# Altium schematic sheet mcu+lora.SchDoc(mcu+lora)
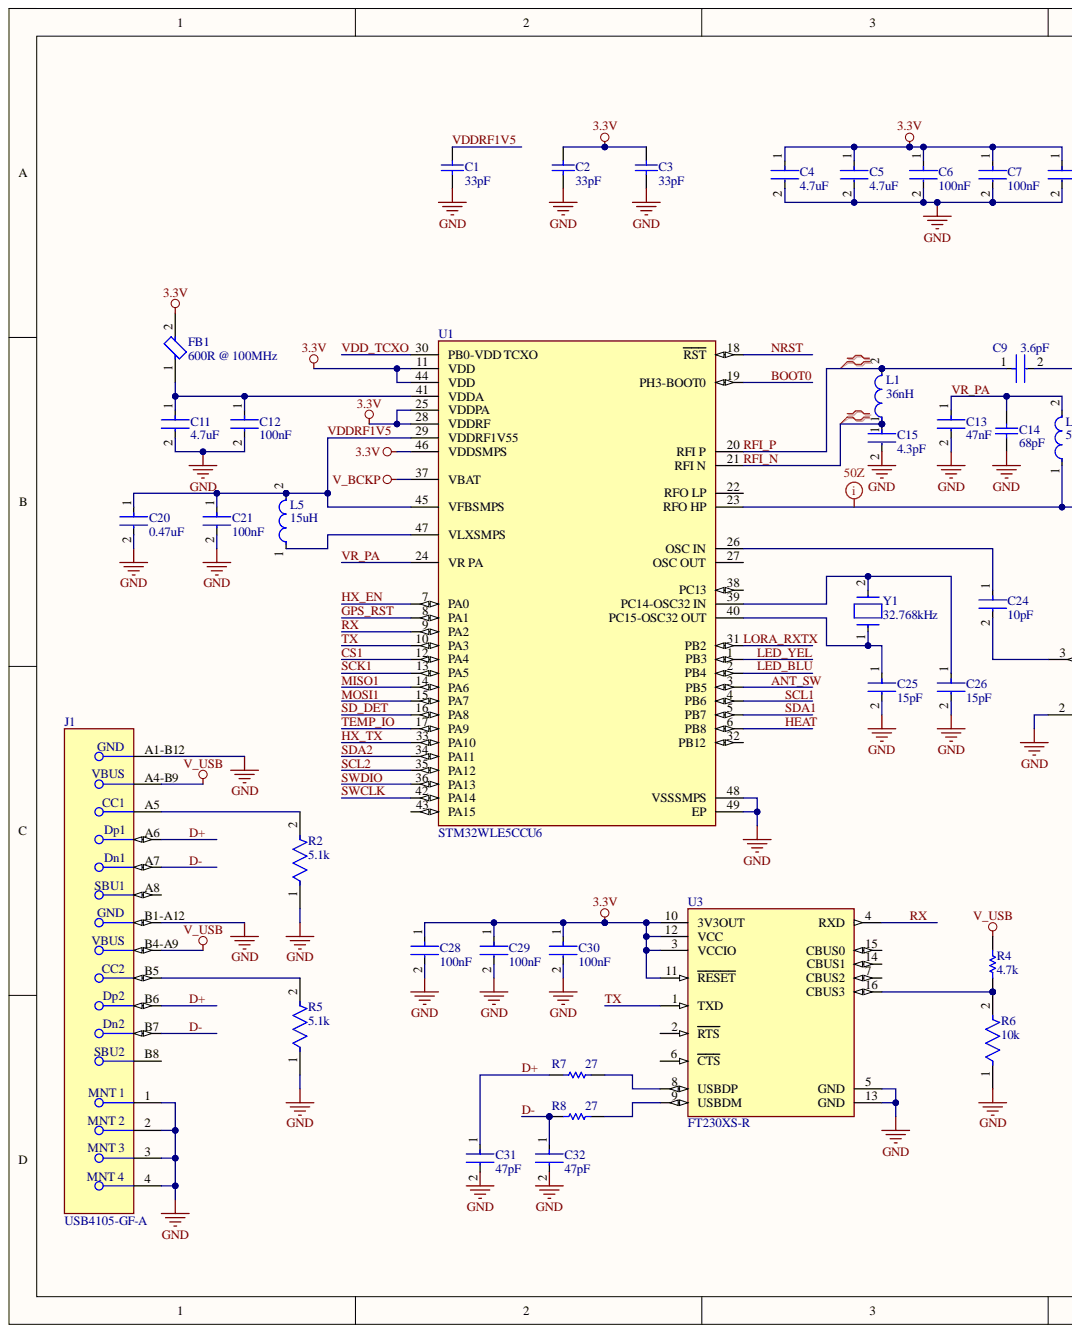
[diagram p0: mcu+lora.SchDoc, left]
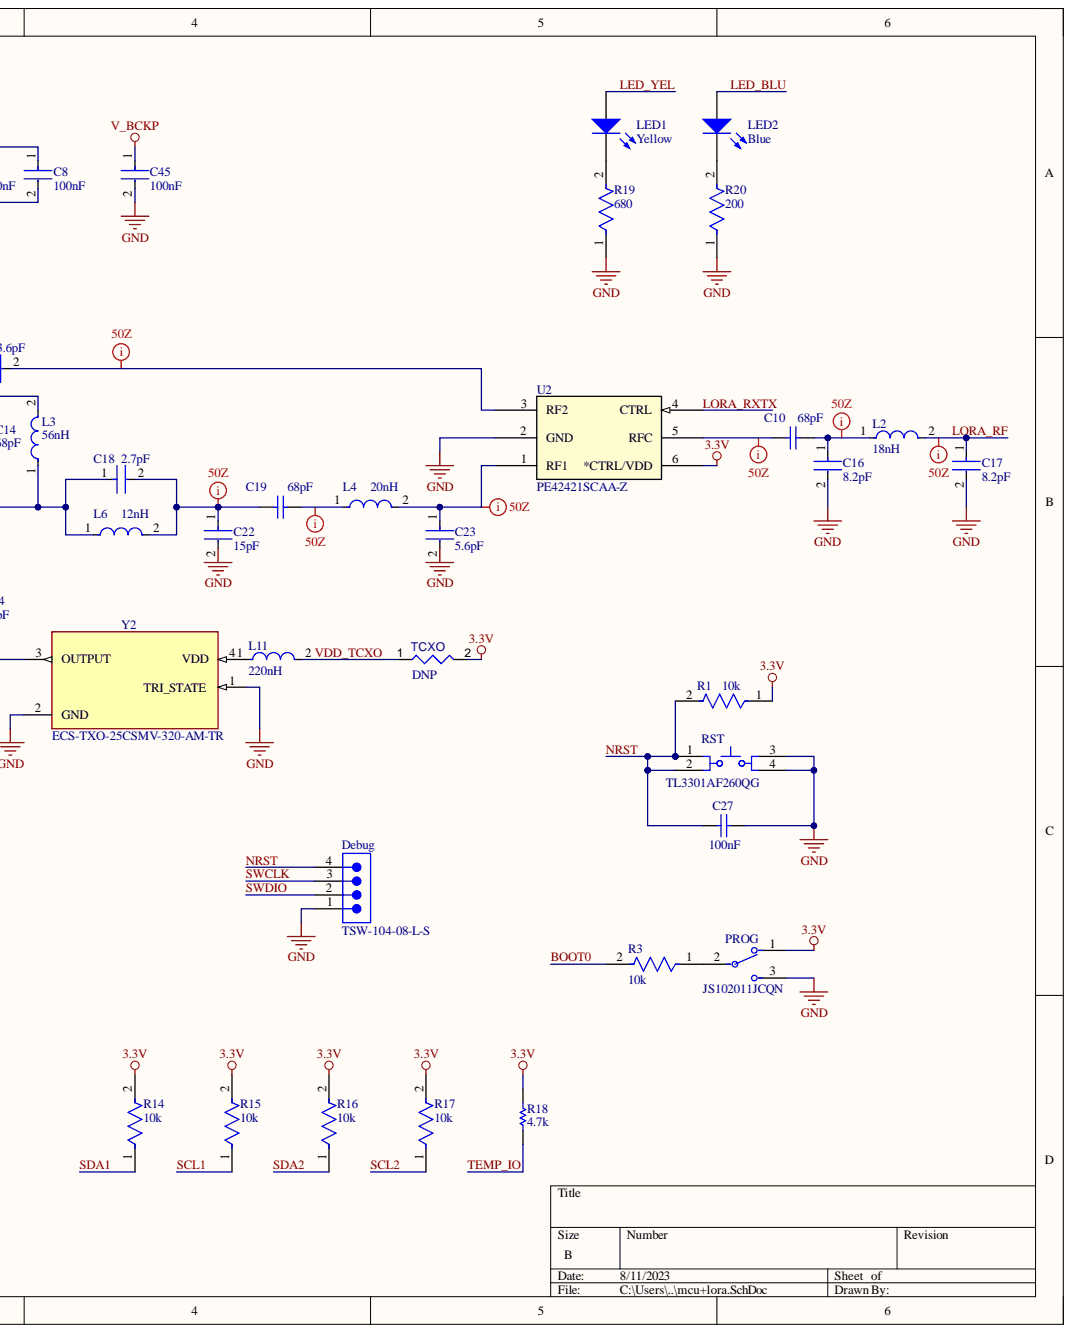
[diagram p1: mcu+lora.SchDoc, right]

## Parts
C1  33pF  [CAPC1608X90X35LL15T15]
C2  33pF  [CAPC1608X90X35LL15T15]
C3  33pF  [CAPC1608X90X35LL15T15]
C4  4.7uF  [FP-CL21-IPC_C]
C5  4.7uF  [FP-CL21-IPC_C]
C6  100nF  [FP-C0603C-CF-MFG]
C7  100nF  [FP-C0603C-CF-MFG]
C8  100nF  [FP-C0603C-CF-MFG]
C9  3.6pF  [FP-GJM155-0_05-IPC_A]
C10  68pF  [CAPC1005X55X25ML05T10]
C11  4.7uF  [FP-CL21-IPC_C]
C12  100nF  [FP-C0603C-CF-MFG]
C13  47nF  [FP-GRM155-0_05-IPC_C]
C14  68pF  [CAPC1005X55X25ML05T10]
C15  4.3pF  [FP-GJM155-0_05-IPC_A]
C16  8.2pF  [FP-GJM155-0_05-MFG]
C17  8.2pF  [FP-GJM155-0_05-MFG]
C18  2.7pF  [FP-GJM155-0_05-MFG]
C19  68pF  [CAPC1005X55X25ML05T10]
C20  0.47uF  [FP-CL21-IPC_A]
C21  100nF  [FP-C0603C-CF-MFG]
C22  15pF  [FP-GJM155-0_05-MFG]
C23  5.6pF  [FP-GJM155-0_05-IPC_C]
C24  10pF  [FP-GJM155-0_05-IPC_A]
C25  15pF  [FP-GJM155-0_05-MFG]
C26  15pF  [FP-GJM155-0_05-MFG]
C27  100nF  [CAPC1608X90X35NL15T15]
C28  100nF  [FP-C0603C-CF-MFG]
C29  100nF  [FP-C0603C-CF-MFG]
C30  100nF  [FP-C0603C-CF-MFG]
C31  47pF  [FP-C0603C-CF-MFG]
C32  47pF  [FP-C0603C-CF-MFG]
C45  100nF  [FP-C0603C-CF-MFG]
Debug  TSW-104-08-L-S  [SMTC-TSW-104-08-X-S]
FB1  600R @ 100MHz  Impedance: 600R  [FP-HZ0805-MFG]
J1  USB4105-GF-A  [FP-USB4105-GF-A-MFG]
L1  36nH  [FP-LQW15AN_80-MFG]
L2  18nH  [FP-LQW15AN_00-W0_6-0_1-MFG]
L3  56nH  [FP-LQW15AN_80-MFG]
L4  20nH  [FP-LQW15AN_00-W0_6-0_1-MFG]
L5  15uH  [FP-CB2012-MFG]
L6  12nH  [FP-LQW15AN_00-W0_6-0_1-MFG]
L11  220nH  [FP-MLZ1608-IPC_C]
LED1  Yellow  [1206_A]
LED2  Blue  [1206_A]
PROG  JS102011JCQN  [FP-JS102011JCQN-MFG]
R1  10k  [FP-RT0603-MFG]
R2  5.1k  [FP-RC1608-IPC_C]
R3  10k  [FP-RT0603-MFG]
R4  4.7k  [RESC1608X55X30NL15T15]
R5  5.1k  [FP-RC1608-IPC_C]
R6  10k  [FP-RT0603-MFG]
R7  27  [RESC1608X55X30ML15T15]
R8  27  [RESC1608X55X30ML15T15]
R14  10k  [FP-RT0603-MFG]
R15  10k  [FP-RT0603-MFG]
R16  10k  [FP-RT0603-MFG]
R17  10k  [FP-RT0603-MFG]
R18  4.7k  [RESC1608X55X30NL15T15]
R19  680  [FP-RC0603-0_55-MFG]
R20  200  [FP-RT0603-MFG]
RST  TL3301AF260QG  [ESWI-TL3301AF260QG_V]
TCXO  value DNP  [RESC0603(1608)_L]  (hidden comment: 0R3J)
U1  STM32WLE5CCU6  [FP-UFQFPN48-A0B9-IPC_C]
U2  PE42421SCAA-Z  [SC-70-6_PSM]
U3  FT230XS-R  [FP-SSOP-16-MFG]
Y1  32.768kHz  [FP-ABS05-MFG]
Y2  ECS-TXO-25CSMV-320-AM-TR  [OSC_ECS-2520S25-250-FN-TR]

## Nets
3.3V: C2.2 C3.2 C4.1 C5.1 C6.1 C7.1 C8.1 C28.1 C29.1 C30.1 FB1.2 PROG.1 R1.1 R14.2 R15.2 R16.2 R17.2 R18.2 TCXO.2 U1.11(VDD) U1.25(VDDPA) U1.28(VDDRF) U1.44(VDD) U1.46(VDDSMPS) U2.6(*CTRL/VDD) U3.3(VCCIO) U3.10(3V3OUT) U3.11(RESET) U3.12(VCC)
GND: C1.1 C2.1 C3.1 C4.2 C5.2 C6.2 C7.2 C8.2 C11.2 C12.2 C13.2 C14.1 C15.2 C16.2 C17.2 C20.2 C21.2 C22.2 C23.2 C25.2 C26.2 C27.1 C28.2 C29.2 C30.2 C31.2 C32.2 C45.2 Debug.1 J1.1 J1.2 J1.3 J1.4 J1.A1-B12 J1.B1-A12 PROG.3 R2.1 R5.1 R6.1 R19.1 R20.1 RST.3 RST.4 U1.48(VSSSMPS) U1.49(EP) U2.2(GND) U3.5(GND) U3.13(GND) Y2.1(TRI_STATE) Y2.2(GND)
V_BCKP: C45.1 U1.37(VBAT)
V_USB: J1.A4-B9 J1.B4-A9 R4.2
ANT_SW: U1.3(PB5)
BOOT0: R3.2 U1.19(PH3-BOOT0)
CS1: U1.12(PA4)
D+: C31.1 J1.A6 J1.B6 R7.1
D-: C32.1 J1.A7 J1.B7 R8.1
GPS_RST: U1.8(PA1)
HEAT: U1.6(PB8)
HX_EN: U1.7(PA0)
HX_TX: U1.33(PA10)
LED_BLU: LED2.2 U1.2(PB4)
LED_YEL: LED1.2 U1.1(PB3)
LORA_RF: C17.1 L2.2
LORA_RXTX: U1.31(PB2) U2.4(CTRL)
MISO1: U1.14(PA6)
MOSI1: U1.15(PA7)
NRST: C27.2 Debug.4 R1.2 RST.1 RST.2 U1.18(RST)
RFI_N: C15.1 L1.1 U1.21(RFI_N)
RFI_P: C9.1 L1.2 U1.20(RFI_P)
RX: U1.9(PA2) U3.4(RXD)
SCK1: U1.13(PA5)
SCL1: R15.1 U1.4(PB6)
SCL2: R17.1 U1.35(PA12)
SD_DET: U1.16(PA8)
SDA1: R14.1 U1.5(PB7)
SDA2: R16.1 U1.34(PA11)
SWCLK: Debug.3 U1.42(PA14)
SWDIO: Debug.2 U1.36(PA13)
TEMP_IO: R18.1 U1.17(PA9)
TX: U1.10(PA3) U3.1(TXD)
VDD_TCXO: L11.2 TCXO.1 U1.30(PB0-VDD_TCXO)
VDDRF1V5: C1.2 C20.1 C21.1 L5.2 U1.29(VDDRF1V55) U1.45(VFBSMPS)
VR_PA: C13.1 C14.2 L3.2 U1.24(VR_PA)
NetC9_2: C9.2 U2.3(RF2)
NetC10_1: C10.1 U2.5(RFC)
NetC10_2: C10.2 C16.1 L2.1
NetC11_1: C11.1 C12.1 FB1.1 U1.41(VDDA)
NetC18_1: C18.1 L3.1 L6.1 U1.23(RFO_HP)
NetC18_2: C18.2 C19.1 C22.1 L6.2
NetC19_2: C19.2 L4.1
NetC23_1: C23.1 L4.2 U2.1(RF1)
NetC24_1: C24.1 U1.26(OSC_IN)
NetC24_2: C24.2 Y2.3(OUTPUT)
NetC25_1: C25.1 U1.40(PC15-OSC32_OUT) Y1.1
NetC26_1: C26.1 U1.39(PC14-OSC32_IN) Y1.2
NetJ1_A5: J1.A5 R2.2
NetJ1_B5: J1.B5 R5.2
NetL5_1: L5.1 U1.47(VLXSMPS)
NetL11_1: L11.1 Y2.4(VDD)
NetLED1_1: LED1.1 R19.2
NetLED2_1: LED2.1 R20.2
NetPROG_2: PROG.2 R3.1
NetR4_1: R4.1 R6.2 U3.16(CBUS3)
NetR7_2: R7.2 U3.8(USBDP)
NetR8_2: R8.2 U3.9(USBDM)

Not connected: J1 A8 B8; U1 22(RFO_LP) 27(OSC_OUT) 32(PB12) 38(PC13) 43(PA15); U3 2(RTS) 6(CTS) 7(CBUS2) 14(CBUS1) 15(CBUS0)

## Library data of the parts
C1-C3 = CMP-2006-04137-1: Manufacturer: AVX Interconnect / Elco; Part Number: 06033A330JAT2A; Component Kind: Standard; Library Name: Altium Content Vault
C4 C5 C11 = CMP-13271-004306-1: CL21 Series 0805 4.7uF 50V ±10% Tolerance X5R Multilayer Ceramic Chip Capacitor; Capacitance: 4.7uF; Case Code (Imperial): 0805; Case Code (Metric): 2012; Case/Package: 0805; Component Kind: Standard; Depth: 1.25mm; Dielectric: X5R; Length: 2mm; Library Name: Altium Content Vault; Max Operating Temperature: 85°C; Min Operating Temperature: -55°C; Mount: Surface Mount; Package Quantity: 1; Packaging: Tape and Reel; Radiation Hardening: No; RoHS Compliant: Yes; Series: CL; Thickness: 0.055inch; Tolerance: 10%; Voltage Rating: 50V; Width: 0.049inch
C6-C8 C12 C21 C28-C30 C45 = CMP-2006-03061-2: CAP CER 0.1UF 50V X7R 0603; Manufacturer: KEMET; Manufacturer Part Number: C0603C104K5RACTU; Automotive Grade: Grade 1; Capacitance: 0.10 μF; Material: Ceramic; Temperature Coefficient: 30ppm/°C; Tolerance: 0.1; Value: 0.10 μF; Automotive: Yes; Category: Cap; Component Kind: Standard; ComponentLink2Description: Datasheet; ComponentLink2URL: https://api.kemet.com/component-edge/download/datasheet/C0603C100J5GACAUTO.pdf; Device Class L1: Passive Components; Device Class L2: Capacitors; Device Class L3: Ceramic Capacitors; Digikey Description: CAP CER 0.1UF 50V X7R 0603; Height: 0.87mm; Lead Free: Yes; Library Name: Altium Content Vault; Octopart: https://octopart.com/c0603c104k5ractu-kemet-139244; Package: C0603C-CF; RoHS: TRUE; Temperature: -55°C to +125°C; Temperature Characteristic: X7R; Temperature Range High: +125°C; Temperature Range Low: -55°C; Voltage Rating: 50 V
C9 = CMP-06035-051664-1: Multi-Layer Ceramic Capacitor 3.6pF C0G  ±0.05pF 0402 Paper T/R; Voltage Rating: 50V; Capacitance: 3.6pF; Case Code (Imperial): 0402; Case Code (Metric): 1005; Case/Package: 0402; Component Kind: Standard; Depth: 0.5mm; Dielectric: C0G; Height: 0.5mm; Length: 1mm; Library Name: Altium Content Vault; Max Operating Temperature: 125°C; Min Operating Temperature: -55°C; Mount: Surface Mount; Package Quantity: 10000; Packaging: Tape and Reel; Radiation Hardening: No; Termination: SMD/SMT; Thickness: 0.022inch; Voltage Rating (DC): 50V; Width: 0.02inch
C10 C14 C19 = CMP-2008-04405-1: Manufacturer: Murata; Part Number: GRM1555C1H680GA01D; Component Kind: Standard; Library Name: Altium Content Vault
C13 = CMP-2008-04032-2: Chip Multilayer Ceramic Capacitors for General Purpose, 0402, 47000pF, X7R, 15%, 10%, 25V; Capacitance: 47nF; Case Code (Imperial): 0402; Case Code (Metric): 1005; Case/Package: 0402; Component Kind: Standard; Depth: 0.5mm; Dielectric: X7R; Height: 0.5mm; Length: 1mm; Library Name: Altium Content Vault; Max Operating Temperature: 125°C; Min Operating Temperature: -55°C; Mount: Surface Mount; Package Quantity: 50000; Packaging: Tape and Reel; Radiation Hardening: No; Termination: SMD/SMT; Thickness: 0.022inch; Tolerance: 10%; Voltage Rating: 25V; Voltage Rating (DC): 25V; Width: 0.02inch
C15 = CMP-2008-03858-2: Multi-Layer Ceramic Capacitor 4.3pF C0G  ±0.05pF 0402 Paper T/R; Voltage Rating: 50V; Capacitance: 4.3pF; Case Code (Imperial): 0402; Case Code (Metric): 1005; Case/Package: 0402; Component Kind: Standard; Depth: 0.5mm; Dielectric: C0G; Height: 0.5mm; Length: 1mm; Library Name: Altium Content Vault; Max Operating Temperature: 125°C; Min Operating Temperature: -55°C; Mount: Surface Mount; Package Quantity: 10000; Packaging: Tape and Reel; Radiation Hardening: No; Termination: SMD/SMT; Thickness: 0.022inch; Voltage Rating (DC): 50V; Width: 0.5mm
C16 C17 = CMP-2008-03887-2: Multi-Layer Ceramic Capacitor 8.2pF C0G  ±0.05pF 0402 Paper T/R; Voltage Rating: 50V; Capacitance: 8.2pF; Case Code (Imperial): 0402; Case Code (Metric): 1005; Case/Package: 0402; Component Kind: Standard; Depth: 0.5mm; Dielectric: C0G; Height: 0.5mm; Length: 1mm; Library Name: Altium Content Vault; Max Operating Temperature: 125°C; Min Operating Temperature: -55°C; Mount: Surface Mount; Package Quantity: 10000; Packaging: Tape and Reel; Radiation Hardening: No; Termination: SMD/SMT; Thickness: 0.022inch; Voltage Rating (DC): 50V; Width: 0.02inch
C18 = CMP-2008-03842-2: Multi-Layer Ceramic Capacitor 2.7pF C0G  ±0.05pF 0402 Paper T/R; Voltage Rating: 50V; Capacitance: 2.7pF; Case Code (Imperial): 0402; Case Code (Metric): 1005; Case/Package: 0402; Component Kind: Standard; Depth: 0.5mm; Dielectric: C0G; Height: 0.5mm; Lead Free: Lead Free; Length: 1mm; Library Name: Altium Content Vault; Max Operating Temperature: 125°C; Min Operating Temperature: -55°C; Mount: Surface Mount; Package Quantity: 10000; Packaging: Tape and Reel; Radiation Hardening: No; Termination: SMD/SMT; Thickness: 0.022inch; Voltage Rating (DC): 50V; Width: 0.02inch
C20 = CMP-13271-002452-1: CL21 Series 0805 470nF 50V ±10% Tolerance X7R Multilayer Ceramic Chip Capacitor; Capacitance: 470nF; Case Code (Imperial): 0805; Case Code (Metric): 2012; Case/Package: 0805; Component Kind: Standard; Depth: 1.25mm; Dielectric: X7R; Height: 1.25mm; Lead Free: Lead Free; Length: 2mm; Library Name: Altium Content Vault; Max Operating Temperature: 125°C; Min Operating Temperature: -55°C; Mount: Surface Mount; Package Quantity: 1; Packaging: Tape and Reel; Radiation Hardening: No; Thickness: 0.053inch; Tolerance: 10%; Voltage: 50V; Voltage Rating: 50V; Voltage Rating (DC): 50V; Width: 0.049inch
C22 C25 C26 = CMP-2008-03803-2: Multi-Layer Ceramic Capacitor 15pF C0G  ±2% 0402 Paper T/R; Voltage Rating: 50V; Capacitance: 15pF; Case Code (Imperial): 0402; Case Code (Metric): 1005; Case/Package: 0402; Component Kind: Standard; Depth: 0.5mm; Dielectric: C0G; Height: 0.5mm; Lead Free: Lead Free; Length: 1mm; Library Name: Altium Content Vault; Max Operating Temperature: 125°C; Min Operating Temperature: -55°C; Mount: Surface Mount; Package Quantity: 10000; Packaging: Tape and Reel; Radiation Hardening: No; Termination: SMD/SMT; Thickness: 0.022inch; Tolerance: 2%; Voltage Rating (DC): 50V; Width: 0.02inch
C23 = CMP-2008-03757-2: Multi-Layer Ceramic Capacitor 5.6pF C0G  ±0.05pF 0402 Paper T/R; Voltage Rating: 50V; Capacitance: 5.6pF; Case Code (Imperial): 0402; Case Code (Metric): 1005; Case/Package: 0402; Component Kind: Standard; Depth: 0.5mm; Dielectric: C0G; Height: 0.5mm; Length: 1mm; Library Name: Altium Content Vault; Max Operating Temperature: 125°C; Min Operating Temperature: -55°C; Mount: Surface Mount; Package Quantity: 10000; Packaging: Tape and Reel; Radiation Hardening: No; Termination: SMD/SMT; Thickness: 0.022inch; Voltage Rating (DC): 50V; Width: 0.02inch
C24 = CMP-2008-03797-2: Multi-Layer Ceramic Capacitor 10pF C0G  ±5% 0402 Paper T/R; Voltage: 50V; Capacitance: 10pF; Case Code (Imperial): 0402; Case Code (Metric): 1005; Case/Package: 0402; Component Kind: Standard; Depth: 0.5mm; Dielectric: C0G; Height: 0.5mm; Lead Free: Lead Free; Length: 1mm; Library Name: Altium Content Vault; Max Operating Temperature: 125°C; Min Operating Temperature: -55°C; Mount: Surface Mount; Package Quantity: 1; Packaging: Tape and Reel; Radiation Hardening: No; Termination: SMD/SMT; Thickness: 0.022inch; Tolerance: 5%; Voltage Rating: 50V; Voltage Rating (DC): 50V; Width: 0.02inch
C27 = CMP-2006-04463-1: Manufacturer: AVX Interconnect / Elco; Part Number: 06035D104KAT2A; Component Kind: Standard; Library Name: Altium Content Vault
C31 C32 = CMP-2006-03632-2: CAP CER 47PF 25V 5% NP0 0603; Manufacturer: KEMET; Manufacturer Part Number: C0603C470J3GACTU; Automotive Grade: Grade 1; Material: Ceramic; Temperature Characteristic: C0G; Temperature Coefficient: 30ppm/°C; Tolerance: 0.05; Automotive: Yes; Capacitance: 47 pF; Category: Cap; Component Kind: Standard; ComponentLink2Description: Datasheet; ComponentLink2URL: https://api.kemet.com/component-edge/download/datasheet/C0603C100J5GACAUTO.pdf; Device Class L1: Passive Components; Device Class L2: Capacitors; Device Class L3: Ceramic Capacitors; Digikey Description: CAP CER 47PF 25V 5% NP0 0603; Height: 0.87mm; Lead Free: Yes; Library Name: Altium Content Vault; Mouser Description: Multilayer Ceramic Capacitors MLCC - SMD/SMT 25volts 47pF C0G 5%; Octopart: https://octopart.com/c0603c470j3gactu-kemet-8375742; Package: C0603C-CF; RoHS: TRUE; Temperature: -55°C to +125°C; Temperature Range High: +125°C; Temperature Range Low: -55°C; Value: 47 pF; Voltage Rating: 25 V
Debug = CMP-1024-00358-1: 0.025" SQ Post Header, Through-hole, Vertical, -55 to 125 degC, 2.54 mm Pitch, 4-Pin, Male, RoHS; Manufacturer: Samtec; Pitch: 2.54 mm; Component Kind: Standard; ComponentLink1Description: Manufacturer URL; ComponentLink1URL: http://www.samtec.com; ComponentLink2Description: Datasheet; ComponentLink2URL: http://www.samtec.com/documents/webfiles/pdf/TSW_TH.PDF; ComponentLink3Description: Package Specification; ComponentLink3URL: http://www.samtec.com/documents/webfiles/cpdf/TSW-XXX-XX-XXX-X-XX-XXX-MKT.pdf; DatasheetVersion: F-214; Library Name: Altium Content Vault; Mounting Technology: Through-hole; Orientation: Vertical; PackageDescription: .025[0.64] SQ. Post Terminal Strip Assembly; PackageReference: TSW-104-08-X-S; PackageVersion: DM, 8/1988; PartNumber: TSW-104-08-L-S; RoHS: True; Temperature Range: -55 to 125 degC
FB1 = CMP-2000-05194-2: FERRITE BEAD 600 OHM 0805 1LN; Current Rating: 500mA; DC Resistance (DCR): 300mR; Number of Lines: 1; Case Code (Imperial): 0805; Case Code (Metric): 2012; Case/Package: 0805; Component Kind: Standard; Contact Plating: Tin; Core Material: Ferrite; Depth: 1.45mm; Frequency: 100MHz; Height: 1.25mm; Lead Free: Lead Free; Length: 2mm; Library Name: Altium Content Vault; Material: Ferrite; Max Current Rating: 500mA; Max DC Current: 500mA; Max Operating Temperature: 125°C; Min Operating Temperature: -40°C; Mount: Surface Mount; Package Quantity: 1; Packaging: Tape and Reel; Termination: SMD/SMT; Test Frequency: 100MHz; Weight: 0.00392oz; Width: 0.049inch
J1 = CMP-245163-000001-1: CONN RCPT USB2.0 TYPEC 5A; Manufacturer: GCT; Conn Orientation: Right Angle; Current Rating: 5/6.25/1.25/0.25A; Is Female: yes; Number Of Contacts: 16; Voltage Rating: 20V; Automotive: No; Category: Conn; Component Kind: Standard; ComponentLink2Description: Datasheet; ComponentLink2URL: https://gct.co/files/drawings/usb4105.pdf; Contact Material: Gold,Nickel; Device Class L1: Connectors; Device Class L2: USB Connectors; Device Class L3: unset; Digikey Description: CONN RCPT USB2.0 TYPEC 5A; Height: 3.46mm; Lead Free: Yes; Library Name: Altium Content Vault; Manufacturer Part Number: USB4105-GF-A; Octopart: https://octopart.com/usb4105-gf-a-gct-106627878; Package: 0; RoHS: TRUE; Temperature: -40°C to +85°C; Temperature Range High: +85°C; Temperature Range Low: -40°C; Usb Standard: USB 2.0
L1 = CMP-06042-001852-1: Wire Wound RF Inductor 36nH ±2% 540mA 0.431Ω 0402 (1005); Core Material: Non-Magnetic; DC Resistance (DCR): 431mR; Q Factor: 30; Self Resonant Frequency: 3.5GHz; Shielding: Unshielded; Test Frequency: 100MHz; Case Code (Imperial): 0402; Case Code (Metric): 1005; Case/Package: 0402; Component Kind: Standard; Current Rating: 540mA; Height: 0.5mm; Height - Seated (Max): 0.024inch; Inductance: 36nH; Length: 0.039inch; Library Name: Altium Content Vault; Max DC Current: 540mA; Max Operating Temperature: 125°C; Min Operating Temperature: -55°C; Mount: Surface Mount; Package Quantity: 1; Packaging: Tape and Reel; Resistor Type: Wirewound; Termination: SMD/SMT; Tolerance: 2%; Width: 0.024inch
L2 = CMP-06042-009767-1: Wire Wound RF Inductor 18nH ±2% 370mA 0.27Ω 0402 (1005); Core Material: Air; Current: 280mA; DC Resistance (DCR): 270mR; Q Factor: 25; Self Resonant Frequency: 4.5GHz; Shielding: Unshielded; Test Frequency: 100MHz; Case Code (Imperial): 0402; Case Code (Metric): 1005; Case/Package: 0402; Component Kind: Standard; Current Rating: 370mA; DC Current: 370mA; Depth: 0.7mm; Height: 0.5mm; Height - Seated (Max): 0.024inch; Inductance: 18nH; Inductance Tolerance: 2%; Lead Free: Lead Free; Length: 1mm; Library Name: Altium Content Vault; Max DC Current: 370mA; Max Operating Temperature: 125°C; Military Standard: Not; Min Operating Temperature: -55°C; Mount: Surface Mount; Number of Pins: 2; Package Quantity: 10000; Packaging: Tape and Reel; Radiation Hardening: No; REACH SVHC: No SVHC; Resistor Type: Wirewound; Termination: SMD/SMT; Tolerance: 2%; Weight: 2.8E-05oz; Width: 0.5mm
L3 = CMP-06042-015711-1: Wire Wound RF Inductor 56nH ±2% 340mA 0.996Ω 0402 (1005); Core Material: Non-Magnetic; DC Resistance (DCR): 996mR; Q Factor: 25; Self Resonant Frequency: 2.9GHz; Shielding: Unshielded; Test Frequency: 100MHz; Case Code (Imperial): 0402; Case Code (Metric): 1005; Case/Package: 0402; Component Kind: Standard; Current Rating: 340mA; Height: 0.5mm; Height - Seated (Max): 0.024inch; Inductance: 56nH; Length: 0.039inch; Library Name: Altium Content Vault; Max DC Current: 340mA; Max Operating Temperature: 125°C; Min Operating Temperature: -55°C; Mount: Surface Mount; Package Quantity: 1; Packaging: Tape and Reel; Resistor Type: Wirewound; Termination: SMD/SMT; Tolerance: 2%; Width: 0.5mm
L4 = CMP-06042-008146-1: Wire Wound RF Inductor 20nH ±2% 370mA 0.27Ω 0402 (1005); Core Material: Air; Current: 850mA; DC Resistance (DCR): 270mR; Q Factor: 25; Self Resonant Frequency: 4GHz; Shielding: Unshielded; Test Frequency: 100MHz; Case Code (Imperial): 0402; Case Code (Metric): 1005; Case/Package: 0402; Component Kind: Standard; Current Rating: 370mA; DC Current: 370mA; Depth: 0.7mm; Height: 0.5mm; Inductance: 20nH; Inductance Tolerance: 2%; Lead Free: Lead Free; Length: 1mm; Library Name: Altium Content Vault; Max DC Current: 370mA; Max Operating Temperature: 125°C; Military Standard: Not; Min Operating Temperature: -55°C; Mount: Surface Mount; Package Quantity: 10000; Packaging: Tape and Reel; Radiation Hardening: No; REACH SVHC: No SVHC; Termination: SMD/SMT; Tolerance: 2%; Weight: 2.8E-05oz; Width: 0.02inch
L5 = CMP-14484-000337-1: SMD Inductors 15uH ±10% 0.32A 1.69Ω 0805; Core Material: Ferrite; Current Rating: 320mA; DC Resistance (DCR): 1.69R; Max DC Current: 170mA; Self Resonant Frequency: 28MHz; Shielding: Unshielded; Test Frequency: 2.52MHz; Case Code (Imperial): 0805; Case Code (Metric): 2012; Case/Package: 0805; Component Kind: Standard; Depth: 1.45mm; Halogen Free: Halogen Free; Height: 0.9mm; Height - Seated (Max): 0.057inch; Inductance: 15uH; Lead Free: Lead Free; Length: 0.079inch; Library Name: Altium Content Vault; Max Operating Temperature: 105°C; Min Operating Temperature: -40°C; Mount: Surface Mount; Number of Pins: 2; Package Quantity: 3000; Packaging: Tape and Reel; Radiation Hardening: No; Resistor Type: Wirewound; RoHS Compliant: Yes; Saturation Current: 170mA; Series: CB; Termination: SMD/SMT; Tolerance: 10%; Width: 0.049inch
L6 = CMP-06042-011230-1: Wire Wound RF Inductor 12nH ±2% 500mA 0.14Ω 0402 (1005); Core Material: Non-Magnetic; Current: 1A; DC Resistance (DCR): 140mR; Self Resonant Frequency: 5.5GHz; Shielding: Unshielded; Test Frequency: 100MHz; Case Code (Imperial): 0402; Case Code (Metric): 1005; Case/Package: 0402; Component Kind: Standard; Current Rating: 500mA; DC Current: 500mA; Depth: 0.7mm; Height: 0.5mm; Height - Seated (Max): 0.024inch; Inductance: 12nH; Inductance Tolerance: 2%; Lead Free: Lead Free; Length: 1mm; Library Name: Altium Content Vault; Max DC Current: 500mA; Max Operating Temperature: 125°C; Military Standard: Not; Min Operating Temperature: -55°C; Mount: Surface Mount; Number of Pins: 2; Package Quantity: 10000; Packaging: Tape and Reel; Radiation Hardening: No; REACH SVHC: No SVHC; Resistor Type: Wirewound; Termination: SMD/SMT; Tolerance: 2%; Weight: 2.8E-05oz; Width: 0.02inch
L11 = CMP-08253-000293-1: Shielded Multilayer Inductor 220nH ±20% 600mA 351mΩ SMD 0603; Core Material: Ferrite; DC Resistance (DCR): 351mR; Saturation Current: 550mA; Self Resonant Frequency: 400MHz; Test Frequency: 25MHz; Case Code (Imperial): 0603; Case Code (Metric): 1608; Case/Package: 0603; Component Kind: Standard; Current Rating: 600mA; Height - Seated (Max): 0.037inch; Inductance: 220nH; Lead Free: Lead Free; Length: 0.063inch; Library Name: Altium Content Vault; Max Operating Temperature: 125°C; Min Operating Temperature: -55°C; Mount: Surface Mount; Package Quantity: 4000; Packaging: Tape and Reel; RoHS Compliant: Yes; Shielding: Shielded; Tolerance: 20%; Width: 0.031inch
LED1 = CMP-1426-00019-1: SMD mono-color Chip LED, WL-SMCW, Yellow; Manufacturer: Wuerth Elektronik; PartNumber: 150120YS75000; Color: Yellow; Component Kind: Standard; ComponentLink1Description: Manufacturer URL; ComponentLink1URL: http://www.we-online.com; ComponentLink2Description: Datasheet; ComponentLink2URL: http://katalog.we-online.com/led/datasheet/150120YS75000.pdf; Library Name: Altium Content Vault; PackageReference: 1206_A
LED2 = CMP-1426-00015-1: SMD mono-color Chip LED, WL-SMCW, Blue; Manufacturer: Wuerth Elektronik; PartNumber: 150120BS75000; Color: Blue; Component Kind: Standard; ComponentLink1Description: Manufacturer URL; ComponentLink1URL: http://www.we-online.com; ComponentLink2Description: Datasheet; ComponentLink2URL: http://katalog.we-online.com/led/datasheet/150120BS75000.pdf; Library Name: Altium Content Vault; PackageReference: 1206_A
PROG = CMP-10038-000010-1: SWITCH SLIDE SPDT 300MA 6V; Actuator Length: 2mm; Actuator Material: Nylon; Actuator Type: Standard; Electrical Life: 5000Cycles; Switch Function: On-On; Component Kind: Standard; Contact Material: Copper Alloy; Contact Plating: Silver; Current Rating: 300mA; Library Name: Altium Content Vault; Max Operating Temperature: 85°C; Min Operating Temperature: -40°C; Mount: Surface Mount; Packaging: Cut Tape; Switch Travel: 2mm; Throw Configuration: SPDT; Voltage Rating (DC): 6V
R1 R3 R6 R14-R17 = CMP-2000-04517-2: RES SMD 10K OHM 0.1% 1/10W 0603; Manufacturer: Yageo; Manufacturer Part Number: RT0603BRE0710KL; Power Rating: 0.1W; Resistance: 10KΩ; Temperature Coefficient: 50 ppm/°C; Tolerance: 0.001; Value: 10KΩ; Voltage Rating: 75V; Automotive: No; Category: Res; Component Kind: Standard; ComponentLink2Description: Datasheet; ComponentLink2URL: http://www.yageo.com/documents/recent/PYu-RT_1-to-0.01_RoHS_L_9.pdf; Composition: Thin Film; Device Class L1: Passive Components; Device Class L2: Resistors; Device Class L3: Chip SMD Resistors; Digikey Description: RES SMD 10K OHM 0.1% 1/10W 0603; Footprint Url: http://www.yageo.com/exep/pages/download/literatures/PYu-R_Mount_10.pdf; Height: 0.55mm; Ipc Land Pattern Name: RESC16080X45; Lead Free: Yes; Library Name: Altium Content Vault; Mouser Description: Res Thin Film 0603 10K Ohm 0.1% 1/10W ±50ppm/°C Molded SMD SMD Paper T/R; Octopart: https://octopart.com/rt0603bre0710kl-yageo-1273959; Package: RT0603; RoHS: TRUE; Series: RT; Temperature: -55°C to +155°C; Temperature Range High: +155°C; Temperature Range Low: -55°C
R2 R5 = CMP-13261-005019-1: Res Thick Film 0603 5.1kΩ 1% 0.1W ±100ppm/°C Molded SMD T/R; Max Power Dissipation: 100mW; Resistance: 5.1kR; Voltage Rating (DC): 50V; Case Code (Imperial): 0603; Case Code (Metric): 1608; Case/Package: 0603; Component Kind: Standard; Composition: Thick Film; Contact Plating: Tin; Depth: 0.8mm; Features: Moisture Resistant; Height: 0.022inch; Lead Free: Lead Free; Length: 0.063inch; Library Name: Altium Content Vault; Max Operating Temperature: 155°C; Military Standard: Not; Min Operating Temperature: -55°C; Number of Terminations: 2; Packaging: Tape and Reel; Radiation Hardening: No; Temperature Coefficient: 100ppm/°C; Tolerance: 1%; Width: 0.031inch
R4 R18 = CMP-1012-00586-3: Precision Thick Film Chip Resistor, 4.7 KOhm, +/- 1%, -55 to 155 degC, 0603 (1608 Metric), RoHS, Tape and Reel; Case\Package: 1205; Elements: 4; Power Rating: 250 mW; Resistance: 220 Ohm; Tolerance: 5%; Voltage Rating: 50 V; Case Code (Imperial): 1206; Case Code (Metric): 3216; Component Kind: Standard; ComponentLink1Description: Manufacturer URL; ComponentLink1URL: http://www.panasonic.com/; ComponentLink2Description: Datasheet; ComponentLink2URL: http://industrial.panasonic.com/cdbs/www-data/pdf/RDA0000/AOA0000C86.pdf; DatasheetVersion: Apr-15; Height: 0.024 inch; Length: 0.126 inch; Library Name: Altium Content Vault; Max Operating Temperature: 125 degC; Min Operating Temperature: -55 degC; Mount: Surface Mount; Package Quantity: 1; PackageDescription: 2-Pin Surface Mount Device, Body 1.6 x 0.85 mm; PackageReference: 0603; Packaging: Tape and Reel; Pins: 8; Radiation Hardening: No; REACH SVHC: No SVHC; RoHSCompliant: true; Type (Resistor): Thick Film; Width: 0.063 inch
R7 R8 = CMP-2000-00757-1: Manufacturer: Panasonic; Part Number: ERJ-3GEYJ270V; Component Kind: Standard; Library Name: Altium Content Vault
R19 = CMP-03412-043893-1: RES 680 OHM 1% 1/10W 0603; Power Rating: 100mW; Resistance: 680R; Temperature Coefficient: 100ppm/°C; Voltage Rating: 50V; Case Code (Imperial): 0603; Case Code (Metric): 1608; Case/Package: 0603; Component Kind: Standard; Contact Plating: Tin; Height: 0.45mm; Length: 1.6mm; Library Name: Altium Content Vault; Max Operating Temperature: 155°C; Min Operating Temperature: -55°C; Packaging: Tape and Reel; Radiation Hardening: No; Resistor Type: Thick Film; RoHS Compliant: Yes; Series: RC; Termination: SMD/SMT; Tolerance: 1%; Width: 0.8mm
R20 = CMP-2000-04682-2: RES SMD 200 OHM 1% 1/10W 0603; Manufacturer: Yageo; Manufacturer Part Number: RT0603FRE07200RL; Power Rating: 0.1W; Resistance: 200Ω; Temperature Coefficient: 50 ppm/°C; Tolerance: 0.01; Value: 200Ω; Voltage Rating: 75V; Automotive: No; Category: Res; Component Kind: Standard; ComponentLink2Description: Datasheet; ComponentLink2URL: http://www.yageo.com/documents/recent/PYu-RT_1-to-0.01_RoHS_L_9.pdf; Composition: Thin Film; Device Class L1: Passive Components; Device Class L2: Resistors; Device Class L3: Chip SMD Resistors; Digikey Description: RES SMD 200 OHM 1% 1/10W 0603; Footprint Url: http://www.yageo.com/exep/pages/download/literatures/PYu-R_Mount_10.pdf; Height: 0.55mm; Ipc Land Pattern Name: RESC16080X45; Lead Free: Yes; Library Name: Altium Content Vault; Mouser Description: Res Thin Film 0603 200 Ohm 1% 0.1W(1/10W) ±50ppm/C Epoxy Pad SMD T/R; Octopart: https://octopart.com/rt0603fre07200rl-yageo-1160531; Package: RT0603; RoHS: TRUE; Series: RT; Temperature: -55°C to +155°C; Temperature Range High: +155°C; Temperature Range Low: -55°C
RST = CMP-2000-07249-1: Tactile Switch, SPST-NO, 50 mA, 12 V, -20 to 70 degC, 4-Pin SMD, RoHS, Tape and Reel; Manufacturer: E-Switch; 3D Model: TL3301AFyyyyG.stp; Actuator Height off PCB, from Pins: 5.00mm; Actuator Material: Polyamide(PA),Nylon; Actuator Orientation: TopActuated; Actuator Type: Standard; Catalog Drawings: TL3301xFFootprint; Contact Rating @ Voltage: 0.05A@12VDC; Featured Product: TL3301SeriesTactSwitch; Illumination: Non-Illuminated; Mechanical Life: 100,000Cycles; Online Catalog: TL3301Series; Operating Force: 260gf; Other Names: EG2527DKR; Outline: 6.00mmx6.00mm; Package Version: Rev. D, 10/2014; Product Training Modules: SwitchBasics; Switch Function: Off-Mom; Switch Travel: 0.25mm; Category: Switches; Circuit: SPST-NO; Component Kind: Standard; Datasheet URL: https://www.e-switch.com/system/asset/product_line/data_sheet/185/TL3301.pdf; Datasheet Version: 12/2013; Family: TactileSwitches; Features: -; Illumination Type, Color: -; Illumination Voltage (Nominal): -; Ingress Protection: -; Library Name: Altium Content Vault; Manufacturer URL: http://www.e-switch.ch/en/; Mounting Technology: SurfaceMount; Mounting Type: SurfaceMount; Operating Temperature: -20°C~70°C; Package Description: 4-Pin Surface Mount Device, Body 6 x 6 mm; Package Reference: TL3301AF260QG; Package URL: http://spec_sheets.e-switch.com/specs/P010513.pdf; Packaging: Digi-Reel®; RoHS Information: TL3301SeriesRoHSCertofCompliance; Series: TL3301; Standard Package: 1; Termination Style: GullWing
TCXO = CMP-009-00063-2: Jumper 0603 (1608 Metric); Case/Package: 0603; Component Kind: Standard; Mounting Technology: SMT; Pins: 2; Library Name: Purdue Space Program - High Altitude
U1 = CMP-12105-000100-1: MULTIPROTOCOL LPWAN 32-BIT ARM C; Component Kind: Standard; Library Name: Altium Content Vault
U2 = CMP-059-000000-1: No Description Available; Mfr_Name: pSemi; Component Kind: Standard; Design Item ID: PE42421SCAA-Z; RefDes: RefDes; Source: PE42421SCAA-Z.SchLib; Type: RF Switches; Library Name: Purdue Space Program - High Altitude
U3 = CMP-0248-00026-3: IC USB SERIAL BASIC UART 16SSOP; Data Rate: 12Mbps; Max Supply Voltage: 5.5V; Min Supply Voltage: 2.97V; Number of Channels: 1; Operating Supply Current: 8mA; Operating Supply Voltage: 5V; Case/Package: SSOP; Component Kind: Standard; Height: 0.059inch; Interface: UART; Length: 5mm; Library Name: Altium Content Vault; Max Operating Temperature: 85°C; Min Operating Temperature: -40°C; Mount: Surface Mount; Number of Pins: 16; Package Quantity: 3000; Packaging: Tape and Reel; Weight: 0.004586oz; Width: 0.157inch
Y1 = CMP-27762-008890-2: Crystal 32.768kHz ±20ppm (Tol) 12.5pF FUND 90kΩ 2-Pin SMD T/R; ESR (Equivalent Series Resistance): 90kR; Case/Package: CSMD; Component Kind: Standard; Depth: 1mm; Frequency: 32.768kHz; Frequency Tolerance: 20ppm; Height: 0.5mm; Lead Free: Lead Free; Length: 1.6mm; Library Name: Altium Content Vault; Load Capacitance: 12.5pF; Max Operating Temperature: 85°C; Min Operating Temperature: -40°C; Mount: Surface Mount; Number of Pins: 2; Operating Frequency: 32.768 kHz; Package Quantity: 3000; Packaging: Tape and Reel; Radiation Hardening: No; REACH SVHC: No SVHC; RoHS Compliant: Yes; Series: ABS05; Series Resistance: 90kR; Termination: SMD/SMT; Tolerance: 20ppm; Width: 1mm
Y2 = ECS-TXO-25CSMV-320-AM-TR: miniature SMD HCMOS Tight Stability Crystal Oscillator; MF: {'manufacturer': u'ECS Inc.'}; Availability: Unavailable; Component Kind: Standard; MP: ECS-TXO-25CSMV-320-AM-TR; Package: None; Price: None; Supplier 1: Newark; Supplier Part Number 1: 69AK8445
